# Altium schematic sheet RX.SchDoc(RX)
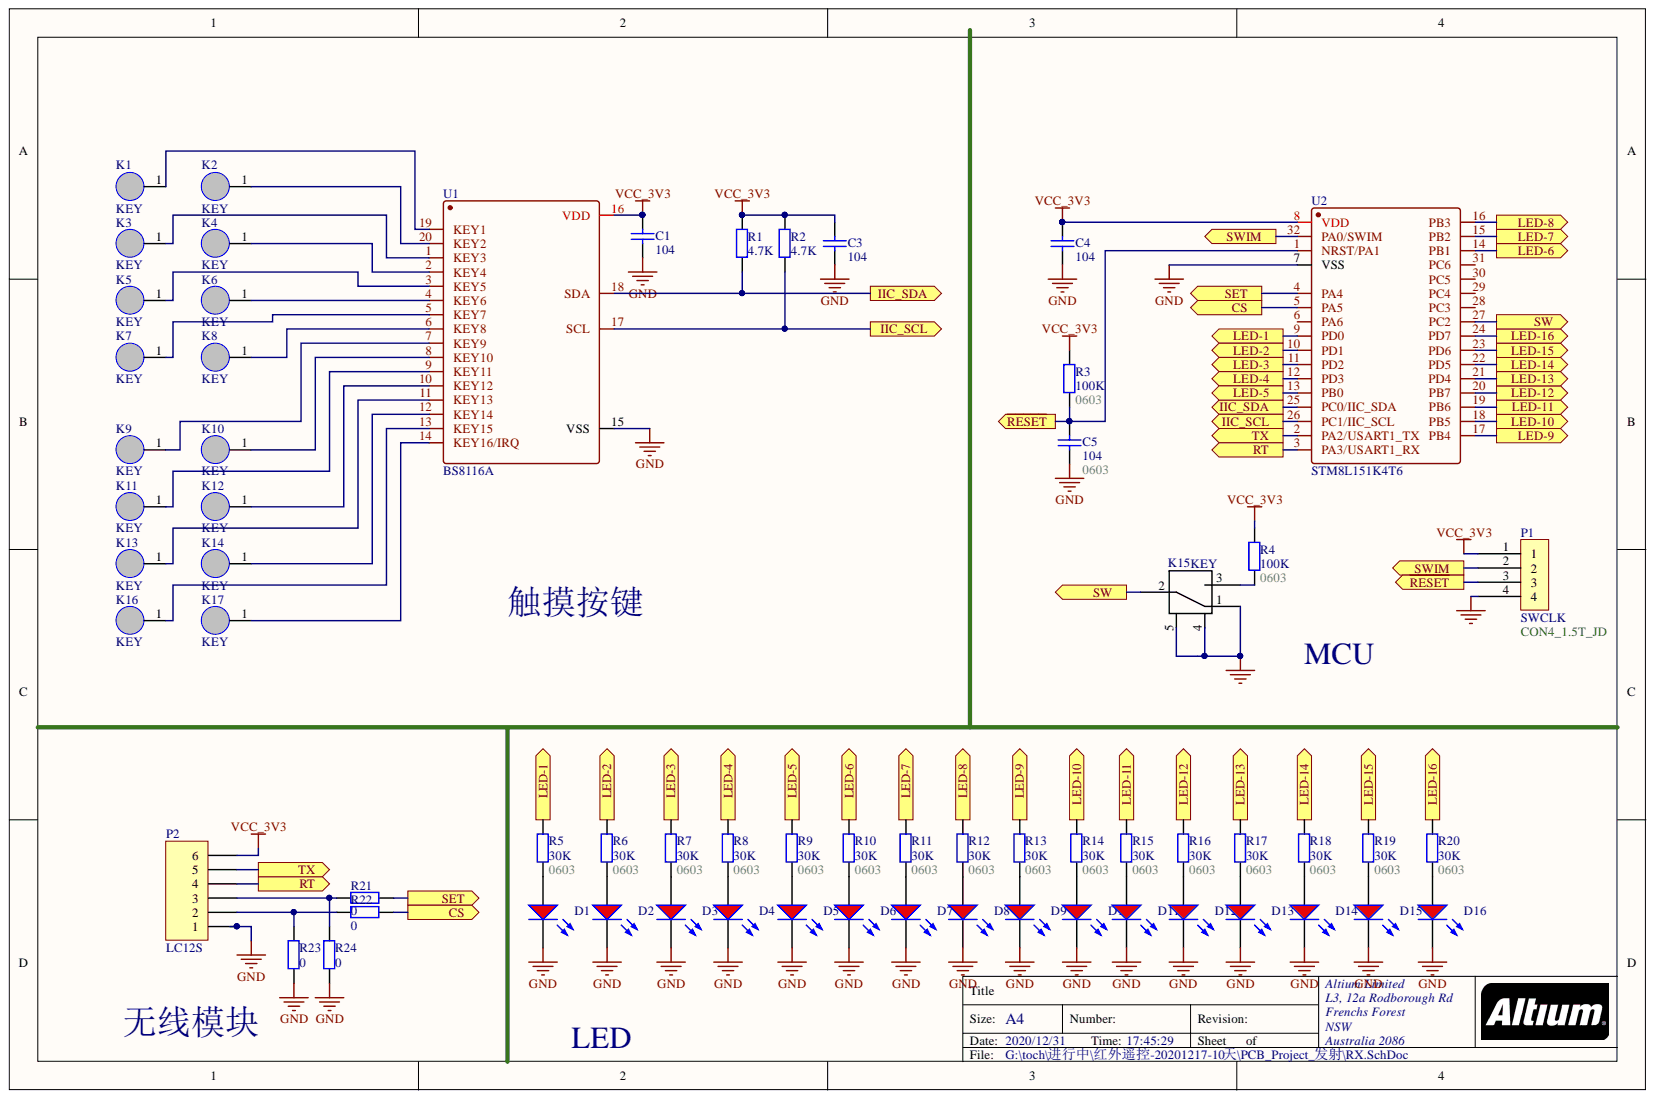
[diagram p0: RX.SchDoc]

## Parts
C1  104  [0603_C]
C3  104  [0603_C]
C4  104  [0603_C]
C5  104  Package: 0603  [0603_C]
D1  Package: 0603  [0603_LED_S1]  (hidden comment: 红灯 0603)
D2  Package: 0603  [0603_LED_S1]  (hidden comment: 红灯 0603)
D3  Package: 0603  [0603_LED_S1]  (hidden comment: 红灯 0603)
D4  Package: 0603  [0603_LED_S1]  (hidden comment: 红灯 0603)
D5  Package: 0603  [0603_LED_S1]  (hidden comment: 红灯 0603)
D6  Package: 0603  [0603_LED_S1]  (hidden comment: 红灯 0603)
D7  Package: 0603  [0603_LED_S1]  (hidden comment: 红灯 0603)
D8  Package: 0603  [0603_LED_S1]  (hidden comment: 红灯 0603)
D9  Package: 0603  [0603_LED_S1]  (hidden comment: 红灯 0603)
D10  Package: 0603  [0603_LED_S1]  (hidden comment: 红灯 0603)
D11  Package: 0603  [0603_LED_S1]  (hidden comment: 红灯 0603)
D12  Package: 0603  [0603_LED_S1]  (hidden comment: 红灯 0603)
D13  Package: 0603  [0603_LED_S1]  (hidden comment: 红灯 0603)
D14  Package: 0603  [0603_LED_S1]  (hidden comment: 红灯 0603)
D15  Package: 0603  [0603_LED_S1]  (hidden comment: 红灯 0603)
D16  Package: 0603  [0603_LED_S1]  (hidden comment: 红灯 0603)
K1  KEY  [key]
K2  KEY  [key]
K3  KEY  [key]
K4  KEY  [key]
K5  KEY  [key]
K6  KEY  [key]
K7  KEY  [key]
K8  KEY  [key]
K9  KEY  [key]
K10  KEY  [key]
K11  KEY  [key]
K12  KEY  [key]
K13  KEY  [key]
K14  KEY  [key]
K15  KEY  [SW]  (value not drawn)
K16  KEY  [key]
K17  KEY  [key]
P1  SWCLK  [xiazai]
P2  LC12S  [HDR1X6]
R1  4.7K  [0603_R]
R2  4.7K  [0603_R]
R3  100K  Package: 0603  [0603_R]
R4  100K  Package: 0603  [0603_R]
R5  30K  Package: 0603  [0603_R]
R6  30K  Package: 0603  [0603_R]
R7  30K  Package: 0603  [0603_R]
R8  30K  Package: 0603  [0603_R]
R9  30K  Package: 0603  [0603_R]
R10  30K  Package: 0603  [0603_R]
R11  30K  Package: 0603  [0603_R]
R12  30K  Package: 0603  [0603_R]
R13  30K  Package: 0603  [0603_R]
R14  30K  Package: 0603  [0603_R]
R15  30K  Package: 0603  [0603_R]
R16  30K  Package: 0603  [0603_R]
R17  30K  Package: 0603  [0603_R]
R18  30K  Package: 0603  [0603_R]
R19  30K  Package: 0603  [0603_R]
R20  30K  Package: 0603  [0603_R]
R21  0  [0603_R]
R22  0  [0603_R]
R23  0  [0603_R]
R24  0  [0603_R]
U1  BS8116A  [BS8116A]
U2  STM8L151K4T6  [STM8L151K4T6]

## Ports
CS, IIC_SCL, IIC_SDA, LED-1, LED-2, LED-3, LED-4, LED-5, LED-6, LED-7, LED-8, LED-9, LED-10, LED-11, LED-12, LED-13, LED-14, LED-15, LED-16, RT, SET, SW, SWIM, TX, ~{RESET}

## Nets
GND: C1.1 C3.1 C4.1 C5.1 D1.K D2.K D3.K D4.K D5.K D6.K D7.K D8.K D9.K D10.K D11.K D12.K D13.K D14.K D15.K D16.K K15.1 K15.4 K15.5 P1.4 P2.1 R23.1 R24.1 U1.15(VSS) U2.7(VSS)
VCC_3V3: C1.2 C3.2 C4.2 P1.1 P2.6 R1.2 R2.2 R3.2 R4.2 U1.16(VDD) U2.8(VDD)
CS: R22.2 U2.5(PA5) ; port CS
IIC_SCL: R2.1 U1.17(SCL) U2.26(PC1/IIC_SCL) ; port IIC_SCL
IIC_SDA: R1.1 U1.18(SDA) U2.25(PC0/IIC_SDA) ; port IIC_SDA
LED-1: R5.2 U2.9(PD0) ; port LED-1
LED-2: R6.2 U2.10(PD1) ; port LED-2
LED-3: R7.2 U2.11(PD2) ; port LED-3
LED-4: R8.2 U2.12(PD3) ; port LED-4
LED-5: R9.2 U2.13(PB0) ; port LED-5
LED-6: R10.2 U2.14(PB1) ; port LED-6
LED-7: R11.2 U2.15(PB2) ; port LED-7
LED-8: R12.2 U2.16(PB3) ; port LED-8
LED-9: R13.2 U2.17(PB4) ; port LED-9
LED-10: R14.2 U2.18(PB5) ; port LED-10
LED-11: R15.2 U2.19(PB6) ; port LED-11
LED-12: R16.2 U2.20(PB7) ; port LED-12
LED-13: R17.2 U2.21(PD4) ; port LED-13
LED-14: R18.2 U2.22(PD5) ; port LED-14
LED-15: R19.2 U2.23(PD6) ; port LED-15
LED-16: R20.2 U2.24(PD7) ; port LED-16
~{RESET}: C5.2 P1.3 R3.1 U2.1(NRST/PA1) ; port ~{RESET}
RT: P2.4 U2.3(PA3/USART1_RX) ; port RT
SET: R21.2 U2.4(PA4) ; port SET
SW: K15.2 U2.27(PC2) ; port SW
SWIM: P1.2 U2.32(PA0/SWIM) ; port SWIM
TX: P2.5 U2.2(PA2/USART1_TX) ; port TX
NetD1_A: D1.A R5.1
NetD2_A: D2.A R6.1
NetD3_A: D3.A R7.1
NetD4_A: D4.A R8.1
NetD5_A: D5.A R9.1
NetD6_A: D6.A R10.1
NetD7_A: D7.A R11.1
NetD8_A: D8.A R12.1
NetD9_A: D9.A R13.1
NetD10_A: D10.A R14.1
NetD11_A: D11.A R15.1
NetD12_A: D12.A R16.1
NetD13_A: D13.A R17.1
NetD14_A: D14.A R18.1
NetD15_A: D15.A R19.1
NetD16_A: D16.A R20.1
NetK1_1: K1.1 U1.19(KEY1)
NetK2_1: K2.1 U1.20(KEY2)
NetK3_1: K3.1 U1.1(KEY3)
NetK4_1: K4.1 U1.2(KEY4)
NetK5_1: K5.1 U1.3(KEY5)
NetK6_1: K6.1 U1.4(KEY6)
NetK7_1: K7.1 U1.5(KEY7)
NetK8_1: K8.1 U1.6(KEY8)
NetK9_1: K9.1 U1.7(KEY9)
NetK10_1: K10.1 U1.8(KEY10)
NetK11_1: K11.1 U1.9(KEY11)
NetK12_1: K12.1 U1.10(KEY12)
NetK13_1: K13.1 U1.11(KEY13)
NetK14_1: K14.1 U1.12(KEY14)
NetK15_3: K15.3 R4.1
NetK16_1: K16.1 U1.13(KEY15)
NetK17_1: K17.1 U1.14(KEY16/IRQ)
NetP2_2: P2.2 R22.1 R23.2
NetP2_3: P2.3 R21.1 R24.2

Not connected: U2 6(PA6) 28(PC3) 29(PC4) 30(PC5) 31(PC6)

## Library data of the parts
C1 = 0603 100nF (104) 10% 50V: 贴片电容; SuppliersPartNumber: C14663; Supplier: LC; Package: 0603; Library Name: Miscellaneous Devices LC.IntLib; ComponentLink1URL: http://www.szlcsc.com/product/details_15331.html; ComponentLink1Description: 供应商链接; Component Kind: Standard
C3 C4 = 0603 10uF (106) 10% 10V: 贴片电容; SuppliersPartNumber: C19702; Supplier: LC; Package: 0603; Library Name: Miscellaneous Devices LC.IntLib; ComponentLink1URL: http://www.szlcsc.com/product/details_20411.html; ComponentLink1Description: 供应商链接; Component Kind: Standard
C5 = 0603 1uF (105) 10% 50V: 贴片电容; SuppliersPartNumber: C15849; Supplier: LC; Library Name: Miscellaneous Devices LC.IntLib; ComponentLink1URL: http://www.szlcsc.com/product/details_16531.html; ComponentLink1Description: 供应商链接; Component Kind: Standard
D1-D16 = 0603 红灯: 发光二极管; SuppliersPartNumber: C2286; Supplier: LC; Library Name: Miscellaneous Devices LC.IntLib; ComponentLink1URL: http://www.szlcsc.com/product/details_2659.html; ComponentLink1Description: 供应商链接; Component Kind: Standard
P1 = SIP_4: CON4_1.5T_JD; Parameter 1: CON4_1.5T_JD; Component Kind: Standard; Library Name: CONNECTER.SchLib
P2 = Header 6: Header, 6-Pin; Signal Integrity: Connector; Library Name: Miscellaneous Connectors.IntLib; LatestRevisionNote: Re-released for DXP Platform.; LatestRevisionDate: 17-Jul-2002; Component Kind: Standard; Publisher: Altium Limited
R1 R2 = 0603 4.7KΩ (4701) 1%: 贴片电阻; SuppliersPartNumber: C23162; Supplier: LC; Package: 0603; Library Name: Miscellaneous Devices LC.IntLib; ComponentLink1URL: http://www.szlcsc.com/product/details_23889.html; ComponentLink1Description: 供应商链接; Component Kind: Standard
R3-R20 = 0603 100KΩ (1003) 1%: 贴片电阻; SuppliersPartNumber: C25803; Supplier: LC; Library Name: Miscellaneous Devices LC.IntLib; ComponentLink1URL: http://www.szlcsc.com/product/details_26546.html; ComponentLink1Description: 供应商链接; Component Kind: Standard
R21-R24 = 0603 0Ω (0R0) 1%: 贴片电阻; SuppliersPartNumber: C21189; Supplier: LC; Package: 0603; Library Name: Miscellaneous Devices LC.IntLib; ComponentLink1URL: http://www.szlcsc.com/product/details_21903.html; ComponentLink1Description: 供应商链接; Component Kind: Standard
K1-K17 = KEY: Library Name: Schlib1.SchLib; Component Kind: Standard
U1 = BS8116A: Library Name: Schlib1.SchLib; Component Kind: Standard
U2 = STM8L151K4T6: Library Name: Schlib1.SchLib; Component Kind: Standard
